# Altium schematic sheet [2] MicroController.SchDoc("[2] MicroController")
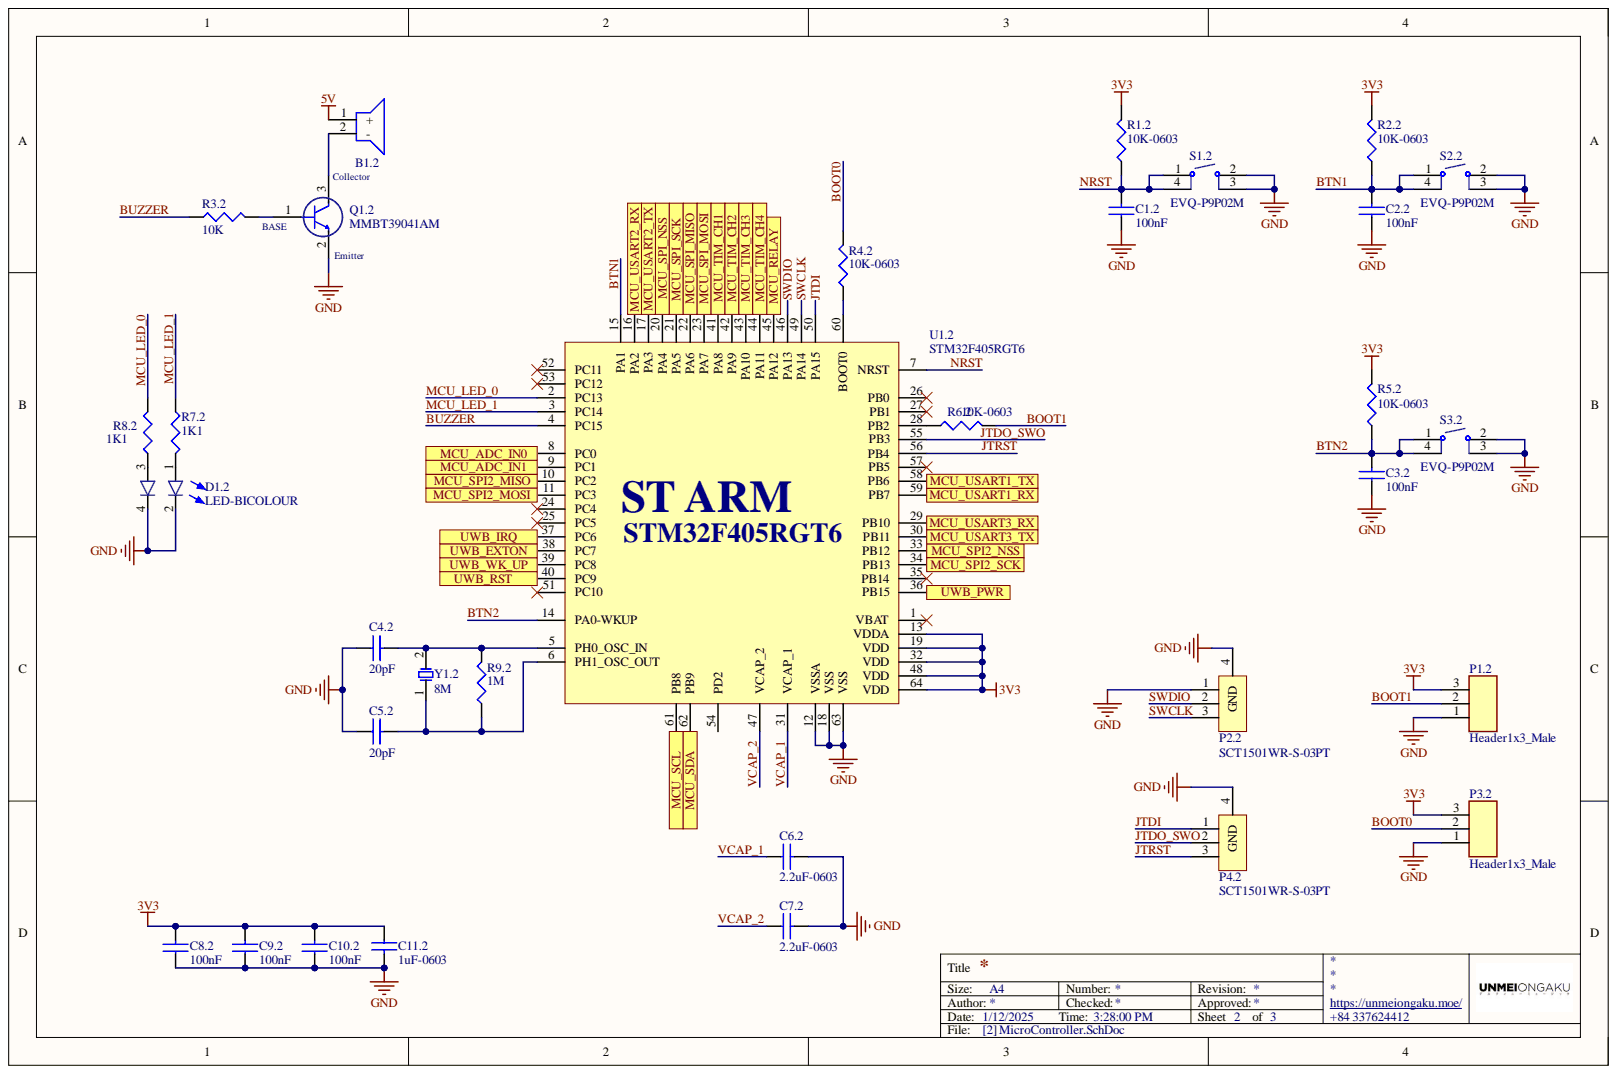
[diagram p0: [2] MicroController.SchDoc]

## Parts
B1.2  Buzzer  [Buzzer 9x5mm 60dB]  (value not drawn)
C1.2  100nF  [CAP0603]
C2.2  100nF  [CAP0603]
C3.2  100nF  [CAP0603]
C4.2  20pF  [CAP0603]
C5.2  20pF  [CAP0603]
C6.2  2.2uF-0603  [CAP0603]
C7.2  2.2uF-0603  [CAP0603]
C8.2  100nF  [CAP0603]
C9.2  100nF  [CAP0603]
C10.2  100nF  [CAP0603]
C11.2  1uF-0603  [CAP0603]
D1.2  LED-BICOLOUR  [LED0805-2COLOUR]
P1.2  Header1x3_Male  [HDR1x3_2.54_MALE]
P2.2  SCT1501WR-S-03PT  [Connector ZH1.5 3P SMD]
P3.2  Header1x3_Male  [HDR1x3_2.54_MALE]
P4.2  SCT1501WR-S-03PT  [Connector ZH1.5 3P SMD]
Q1.2  MMBT39041AM  [SOT23]
R1.2  10K-0603  [RES0603 - 3D]
R2.2  10K-0603  [RES0603 - 3D]
R3.2  10K  [RES0603 - 3D]
R4.2  10K-0603  [RES0603 - 3D]
R5.2  10K-0603  [RES0603 - 3D]
R6.2  10K-0603  [RES0603 - 3D]
R7.2  1K1  [RES0603 - 3D]
R8.2  1K1  [RES0603 - 3D]
R9.2  1M  [RES0603 - 3D]
S1.2  EVQ-P9P02M  [TactileSwitch,SMT,4.2x3.2x2.5mm,4N]
S2.2  EVQ-P9P02M  [TactileSwitch,SMT,4.2x3.2x2.5mm,4N]
S3.2  EVQ-P9P02M  [TactileSwitch,SMT,4.2x3.2x2.5mm,4N]
U1.2  STM32F405RGT6  Core Architecture: ARM  [LQFP64]
Y1.2  8M  [HC49-S]

## Ports
MCU_ADC_IN0, MCU_ADC_IN1, MCU_RELAY, MCU_SCL, MCU_SDA, MCU_SPI2_MISO, MCU_SPI2_MOSI, MCU_SPI2_NSS, MCU_SPI2_SCK, MCU_SPI_MISO, MCU_SPI_MOSI, MCU_SPI_NSS, MCU_SPI_SCK, MCU_TIM_CH1, MCU_TIM_CH2, MCU_TIM_CH3, MCU_TIM_CH4, MCU_USART1_RX, MCU_USART1_TX, MCU_USART2_RX, MCU_USART2_TX, MCU_USART3_RX, MCU_USART3_TX, UWB_EXTON, UWB_IRQ, UWB_PWR, UWB_RST, UWB_WK_UP

## Nets
3V3: C8.2.2 C9.2.2 C10.2.2 C11.2.1 P1.2.3 P3.2.3 R1.2.2 R2.2.2 R5.2.2 U1.2.13(VDDA) U1.2.19(VDD) U1.2.32(VDD) U1.2.48(VDD) U1.2.64(VDD)
5V: B1.2.1
GND: C1.2.1 C2.2.1 C3.2.1 C4.2.1 C5.2.1 C6.2.2 C7.2.2 C8.2.1 C9.2.1 C10.2.1 C11.2.2 D1.2.2 D1.2.4 P1.2.1 P2.2.1 P2.2.4(GND) P3.2.1 P4.2.4(GND) Q1.2.2 S1.2.2 S1.2.3 S2.2.2 S2.2.3 S3.2.2 S3.2.3 U1.2.12(VSSA) U1.2.18(VSS) U1.2.63(VSS)
BOOT0: P3.2.2 R4.2.2
BOOT1: P1.2.2 R6.2.2
BTN1: C2.2.2 R2.2.1 S2.2.1 S2.2.4 U1.2.15(PA1)
BTN2: C3.2.2 R5.2.1 S3.2.1 S3.2.4 U1.2.14(PA0-WKUP)
BUZZER: R3.2.1 U1.2.4(PC15)
JTDI: P4.2.1 U1.2.50(PA15)
JTDO_SWO: P4.2.2 U1.2.55(PB3)
JTRST: P4.2.3 U1.2.56(PB4)
MCU_ADC_IN0: U1.2.8(PC0) ; port MCU_ADC_IN0
MCU_ADC_IN1: U1.2.9(PC1) ; port MCU_ADC_IN1
MCU_LED_0: R8.2.2 U1.2.2(PC13)
MCU_LED_1: R7.2.1 U1.2.3(PC14)
MCU_RELAY: U1.2.45(PA12) ; port MCU_RELAY
MCU_SCL: U1.2.61(PB8) ; port MCU_SCL
MCU_SDA: U1.2.62(PB9) ; port MCU_SDA
MCU_SPI2_MISO: U1.2.10(PC2) ; port MCU_SPI2_MISO
MCU_SPI2_MOSI: U1.2.11(PC3) ; port MCU_SPI2_MOSI
MCU_SPI2_NSS: U1.2.33(PB12) ; port MCU_SPI2_NSS
MCU_SPI2_SCK: U1.2.34(PB13) ; port MCU_SPI2_SCK
MCU_SPI_MISO: U1.2.22(PA6) ; port MCU_SPI_MISO
MCU_SPI_MOSI: U1.2.23(PA7) ; port MCU_SPI_MOSI
MCU_SPI_NSS: U1.2.20(PA4) ; port MCU_SPI_NSS
MCU_SPI_SCK: U1.2.21(PA5) ; port MCU_SPI_SCK
MCU_TIM_CH1: U1.2.41(PA8) ; port MCU_TIM_CH1
MCU_TIM_CH2: U1.2.42(PA9) ; port MCU_TIM_CH2
MCU_TIM_CH3: U1.2.43(PA10) ; port MCU_TIM_CH3
MCU_TIM_CH4: U1.2.44(PA11) ; port MCU_TIM_CH4
MCU_USART1_RX: U1.2.59(PB7) ; port MCU_USART1_RX
MCU_USART1_TX: U1.2.58(PB6) ; port MCU_USART1_TX
MCU_USART2_RX: U1.2.16(PA2) ; port MCU_USART2_RX
MCU_USART2_TX: U1.2.17(PA3) ; port MCU_USART2_TX
MCU_USART3_RX: U1.2.29(PB10) ; port MCU_USART3_RX
MCU_USART3_TX: U1.2.30(PB11) ; port MCU_USART3_TX
NRST: C1.2.2 R1.2.1 S1.2.1 S1.2.4 U1.2.7(NRST)
SWCLK: P2.2.3 U1.2.49(PA14)
SWDIO: P2.2.2 U1.2.46(PA13)
UWB_EXTON: U1.2.38(PC7) ; port UWB_EXTON
UWB_IRQ: U1.2.37(PC6) ; port UWB_IRQ
UWB_PWR: U1.2.36(PB15) ; port UWB_PWR
UWB_RST: U1.2.40(PC9) ; port UWB_RST
UWB_WK_UP: U1.2.39(PC8) ; port UWB_WK_UP
VCAP_1: C6.2.1 U1.2.31(VCAP_1)
VCAP_2: C7.2.1 U1.2.47(VCAP_2)
NetB1.2_2: B1.2.2 Q1.2.3
NetC4.2_2: C4.2.2 R9.2.2 U1.2.5(PH0_OSC_IN) Y1.2.2
NetC5.2_2: C5.2.2 R9.2.1 U1.2.6(PH1_OSC_OUT) Y1.2.1
NetD1.2_1: D1.2.1 R7.2.2
NetD1.2_3: D1.2.3 R8.2.1
NetQ1.2_1: Q1.2.1 R3.2.2
NetR4.2_1: R4.2.1 U1.2.60(BOOT0)
NetR6.2_1: R6.2.1 U1.2.28(PB2)

Not connected: U1.2 1(VBAT) 24(PC4) 25(PC5) 26(PB0) 27(PB1) 35(PB14) 51(PC10) 52(PC11) 53(PC12) 54(PD2) 57(PB5)

## Library data of the parts
B1.2 = Buzzer 9050 - DIP: 9050 DIP Buzzer 9x5mm 60dB; Component Kind: Standard; Local Supplier: thegioiic; Local Supplier Link: https://www.thegioiic.com/products/1209-dip-buzzer-12x9mm; Local Supplier Part Number: 1209 DIP Buzzer 12x9mm; Library Name: Minh_Lib.SchLib
C1.2 C2.2 C3.2 C8.2 C9.2 C10.2 = CC0603KRX7R0BB104: CAP CER 0.1UF 100V X7R 0603; Manufacturer: Yageo; Tolerance: ±10%; Applications: General Purpose; Category: Capacitors; Component Kind: Standard; ComponentLink1Description: http://media.digikey.com/pdf/Data%20Sheets/Yageo%20PDFs/NPO,%20X7R%20Mid-voltage.pdf; ComponentLink1URL: http://media.digikey.com/pdf/Data%20Sheets/Yageo%20PDFs/NPO,%20X7R%20Mid-voltage.pdf; Failure Rate: -; Features: -; Height - Seated (Max): -; Lead Spacing: -; Lead Style: -; Manufacturer Part Number: CC0603KRX7R0BB104; Mounting Type: Surface Mount, MLCC; Operating Temperature: -55°C ~ 125°C; Package / Case: 0603 (1608 Metric); Packaging: Cut Tape (CT); Part Status: Active; Pricing 1: 1=0.23, 10=0.156, 100=0.0791, 500=0.05414, 1000=0.0479 (USD); Ratings: -; RoHS: RoHS Compliant; Size / Dimension: 0.063" L x 0.032" W (1.60mm x 0.80mm); Stock 1: 784508; Supplier 1: Digi-Key; Supplier Part Number 1: 311-1523-1-ND; Temperature Coefficient: X7R; Thickness (Max): 0.035" (0.90mm); Value: 100nF; Voltage - Rated: 100V; Library Name: Minh_Lib.SchLib
C4.2 C5.2 = CC0603JRNPO9BN200: CAP CER 20PF 50V C0G/NPO 0603; Manufacturer 1: Yageo; Category 1: Capacitors; Temperature Coefficient: C0G, NP0; Tolerance: ±5%; Applications: General Purpose; Capacitance: 20pF; Component Kind: Standard; ComponentLink1Description: http://www.yageo.com/documents/recent/UPY-GP_NP0_16V-to-50V_16.pdf; ComponentLink1URL: http://www.yageo.com/documents/recent/UPY-GP_NP0_16V-to-50V_16.pdf; Description 1: CAP CER 20PF 50V C0G/NPO 0603; Failure Rate: -; Features: -; Height - Seated (Max): -; Lead Spacing: -; Lead Style: -; Manufacturer Part Number 1: CC0603JRNPO9BN200; Mounting Type: Surface Mount, MLCC; Operating Temperature: -55°C ~ 125°C; Package / Case: 0603 (1608 Metric); Packaging: Cut Tape (CT); Part Status: Active; Pricing 1: 1=0.1, 10=0.037, 100=0.0164, 500=0.01172, 1000=0.0092 (USD); Ratings: -; RoHS 1: RoHS Compliant; Size / Dimension: 0.063" L x 0.031" W (1.60mm x 0.80mm); Stock 1: 67895; Supplier 1: Digi-Key; Supplier Part Number 1: 311-1424-1-ND; Thickness (Max): 0.035" (0.90mm); Value: 20pF; Voltage - Rated: 50V; Library Name: Minh_Lib.SchLib
C6.2 C7.2 = CC0603KRX7R6BB225: CAP CER 2.2UF 10V X7R 0603; Manufacturer 1: Yageo; Capacitance: 2.2µF; Category 1: Capacitors; Tolerance: ±10%; Applications: General Purpose; Component Kind: Standard; ComponentLink1Description: http://www.yageo.com/documents/recent/UPY-GPHC_X7R_6.3V-to-50V_18.pdf; ComponentLink1URL: http://www.yageo.com/documents/recent/UPY-GPHC_X7R_6.3V-to-50V_18.pdf; Description 1: CAP CER 2.2UF 10V X7R 0603; Failure Rate: -; Features: -; Height - Seated (Max): -; Lead Spacing: -; Lead Style: -; Manufacturer Part Number 1: CC0603KRX7R6BB225; Mounting Type: Surface Mount, MLCC; Operating Temperature: -55°C ~ 125°C; Package / Case: 0603 (1608 Metric); Packaging: Cut Tape (CT); Part Status: Active; Pricing 1: 1=0.32, 10=0.219, 100=0.1238, 500=0.0874, 1000=0.07648 (USD); Ratings: -; RoHS 1: RoHS Compliant; Size / Dimension: 0.063" L x 0.031" W (1.60mm x 0.80mm); Stock 1: 14291; Supplier 1: Digi-Key; Supplier Part Number 1: 311-1797-1-ND; Temperature Coefficient: X7R; Thickness (Max): 0.035" (0.90mm); Value: 2.2uF; Voltage - Rated: 10V; Library Name: Minh_Lib.SchLib
C11.2 = CC0603KRX7R7BB105: CAP CER 1UF 16V X7R 0603; Manufacturer 1: Yageo; Capacitance: 1µF; Category 1: Capacitors; Tolerance: ±10%; Applications: General Purpose; Component Kind: Standard; ComponentLink1Description: http://www.yageo.com/documents/recent/UPY-GPHC_X7R_6.3V-to-50V_18.pdf; ComponentLink1URL: http://www.yageo.com/documents/recent/UPY-GPHC_X7R_6.3V-to-50V_18.pdf; Description 1: CAP CER 1UF 16V X7R 0603; Failure Rate: -; Features: -; Height - Seated (Max): -; Lead Spacing: -; Lead Style: -; Manufacturer Part Number 1: CC0603KRX7R7BB105; Mounting Type: Surface Mount, MLCC; Operating Temperature: -55°C ~ 125°C; Package / Case: 0603 (1608 Metric); Packaging: Cut Tape (CT); Part Status: Active; Pricing 1: 1=0.1, 10=0.051, 100=0.0227, 500=0.0162, 1000=0.01274 (USD); Ratings: -; RoHS 1: RoHS Compliant; Size / Dimension: 0.063" L x 0.031" W (1.60mm x 0.80mm); Stock 1: 274580; Supplier 1: Digi-Key; Supplier Part Number 1: 311-1446-1-ND; Temperature Coefficient: X7R; Thickness (Max): 0.035" (0.90mm); Value: 1uF; Voltage - Rated: 16V; Library Name: Minh_Lib.SchLib
P1.2 P3.2 = HDR1x3_2.54_MALE: Header1x3_2.54_MALE; Component Kind: Standard; Library Name: Minh_Lib.SchLib
Q1.2 = MMBT3904-7-F / 1AM: Transistor General Purpose BJT NPN 40 Volt 0.2A Automotive 3-Pin SOT-23; Manufacturer: Diodes Zetex; Collector Base Voltage (VCBO): 60V; Collector Emitter Breakdown Voltage: 40V; Collector Emitter Saturation Voltage: 300mV; Continuous Collector Current: 200mA; Element Configuration: Single; Emitter Base Voltage (VEBO): 6V; Frequency: 300MHz; hFE Min: 100; Max Power Dissipation: 300mW; Number of Elements: 1; Power Dissipation: 350mW; Case/Package: SOT-23; Category: BJTs; Collector Emitter Voltage (VCEO): 40V; Collector-emitter Voltage-Max: 300mV; Component Kind: Standard; ComponentLink1Description: https://datasheet.ciiva.com/11201/ds30036-11201778.pdf?src-supplier=Avnet; ComponentLink1URL: https://datasheet.ciiva.com/11201/ds30036-11201778.pdf?src-supplier=Avnet; ComponentLink2Description: https://datasheet.ciiva.com/15138/getdatasheetpartid-53265-15138780.pdf?src-supplier=Verical; ComponentLink2URL: https://datasheet.ciiva.com/15138/getdatasheetpartid-53265-15138780.pdf?src-supplier=Verical; ComponentLink3Description: https://datasheet.ciiva.com/22711/1915516-22711750.pdf?src-supplier=Element14; ComponentLink3URL: https://datasheet.ciiva.com/22711/1915516-22711750.pdf?src-supplier=Element14; ComponentLink4Description: https://datasheet.ciiva.com/11198/ds30036-11198999.pdf?src-supplier=Avnet; ComponentLink4URL: https://datasheet.ciiva.com/11198/ds30036-11198999.pdf?src-supplier=Avnet; ComponentLink5Description: https://datasheet.ciiva.com/6361/ds30036-6361256.pdf?src-supplier=Digi-Key; ComponentLink5URL: https://datasheet.ciiva.com/6361/ds30036-6361256.pdf?src-supplier=Digi-Key; ComponentLink6Description: https://datasheet.ciiva.com/6361/ds30036-6361254.pdf?src-supplier=Digi-Key; ComponentLink6URL: https://datasheet.ciiva.com/6361/ds30036-6361254.pdf?src-supplier=Digi-Key; Contact Plating: Tin,Matte; Gain Bandwidth Product: 300MHz; Height: 1mm; Lead Free: Lead Free; Length: 3.05mm; Manufacturer Part Number: MMBT3904-7-F; Max Breakdown Voltage: 40V; Max Collector Current: 200mA; Max Frequency: 300MHz; Max Operating Temperature: 150°C; Min Operating Temperature: -55°C; Mount: Surface Mount; Number of Pins: 3; Package Quantity: 3000; Packaging: Tape and Reel; Polarity: NPN; Pricing 1: 3000=0.02271, 6000=0.02048, 15000=0.01781, 30000=0.01603, 75000=0.01425 (USD); Radiation Hardening: No; REACH SVHC: No SVHC; RoHS: Yes; RoHS Compliant: Yes; Series: -; Stock 1: 468030; Supplier 1: Digi-Key; Supplier Part Number 1: MMBT3904-FDITR-ND; Transition Frequency: 300MHz; Voltage Rating (DC): 40V; Weight: 0.000282oz; Width: 1.4mm; Library Name: Minh_Lib.SchLib
R1.2 R2.2 R4.2 R5.2 R6.2 = RC0603JR-0710KL: RES SMD 10K OHM 5% 1/10W 0603; Manufacturer 1: Yageo; Category 1: Resistors; Power (Watts): 0.1W, 1/10W; Temperature Coefficient: ±100ppm/°C; Component Kind: Standard; ComponentLink1Description: http://www.yageo.com/documents/recent/PYu-RC_Group_51_RoHS_L_7.pdf; ComponentLink1URL: http://www.yageo.com/documents/recent/PYu-RC_Group_51_RoHS_L_7.pdf; Composition: Thick Film; Description 1: RES SMD 10K OHM 5% 1/10W 0603; Failure Rate: -; Features: Moisture Resistant; Height - Seated (Max): 0.022" (0.55mm); Manufacturer Part Number 1: RC0603JR-0710KL; Operating Temperature: -55°C ~ 155°C; Package / Case: 0603 (1608 Metric); Packaging: Cut Tape (CT); Part Status: Active; Pricing 1: 1=0.1, 10=0.011, 100=0.0044, 1000=0.00198, 2500=0.00172 (USD); Resistance (Ohms): 10k; RoHS 1: RoHS Compliant; Size / Dimension: 0.063" L x 0.031" W (1.60mm x 0.80mm); Stock 1: 3056463; Supplier 1: Digi-Key; Supplier Device Package: 0603; Supplier Part Number 1: 311-10KGRCT-ND; Tolerance: ±5%; Value: 10K; Library Name: Minh_Lib.SchLib
R3.2 = RC0603FR-0710KL: RES SMD 10K OHM 1% 1/10W 0603; Manufacturer: Yageo; Power (Watts): 0.1W, 1/10W; Temperature Coefficient: ±100ppm/°C; Category: Resistors; Component Kind: Standard; ComponentLink1Description: http://www.yageo.com/documents/recent/PYu-RC_Group_51_RoHS_L_7.pdf; ComponentLink1URL: http://www.yageo.com/documents/recent/PYu-RC_Group_51_RoHS_L_7.pdf; Composition: Thick Film; Failure Rate: -; Features: Moisture Resistant; Height - Seated (Max): 0.022" (0.55mm); Manufacturer Part Number: RC0603FR-0710KL; Operating Temperature: -55°C ~ 155°C; Package / Case: 0603 (1608 Metric); Packaging: Cut Tape (CT); Part Status: Active; Pricing 1: 1=0.1, 10=0.015, 100=0.006, 1000=0.00268, 2500=0.00233 (USD); RoHS: RoHS Compliant; Size / Dimension: 0.063" L x 0.032" W (1.60mm x 0.80mm); Stock 1: 16545228; Supplier 1: Digi-Key; Supplier Device Package: 0603; Supplier Part Number 1: 311-10.0KHRCT-ND; Tolerance: ±1%; Value: 10K; Library Name: Minh_Lib.SchLib
R7.2 R8.2 = RC0603FR-071K1L: RES SMD 1.1K OHM 1% 1/10W 0603; Manufacturer: Yageo; Power (Watts): 0.1W, 1/10W; Temperature Coefficient: ±100ppm/°C; Category: Resistors; Component Kind: Standard; ComponentLink1Description: http://www.yageo.com/documents/recent/PYu-RC_Group_51_RoHS_L_7.pdf; ComponentLink1URL: http://www.yageo.com/documents/recent/PYu-RC_Group_51_RoHS_L_7.pdf; Composition: Thick Film; Failure Rate: -; Features: Moisture Resistant; Height - Seated (Max): 0.022" (0.55mm); Manufacturer Part Number: RC0603FR-071K1L; Operating Temperature: -55°C ~ 155°C; Package / Case: 0603 (1608 Metric); Packaging: Cut Tape (CT); Part Status: Active; Pricing 1: 1=0.1, 10=0.015, 100=0.006, 1000=0.00268, 2500=0.00233 (USD); RoHS: RoHS Compliant; Size / Dimension: 0.063" L x 0.032" W (1.60mm x 0.80mm); Stock 1: 427612; Supplier 1: Digi-Key; Supplier Device Package: 0603; Supplier Part Number 1: 311-1.10KHRCT-ND; Tolerance: ±1%; Value: 1K1; Library Name: Minh_Lib.SchLib
R9.2 = RC0603JR-071ML: RES SMD 1M OHM 5% 1/10W 0603; Manufacturer 1: Yageo; Category 1: Resistors; Power (Watts): 0.1W, 1/10W; Temperature Coefficient: ±100ppm/°C; Component Kind: Standard; ComponentLink1Description: http://www.yageo.com/documents/recent/PYu-RC_Group_51_RoHS_L_7.pdf; ComponentLink1URL: http://www.yageo.com/documents/recent/PYu-RC_Group_51_RoHS_L_7.pdf; Composition: Thick Film; Description 1: RES SMD 1M OHM 5% 1/10W 0603; Failure Rate: -; Features: Moisture Resistant; Height - Seated (Max): 0.022" (0.55mm); Manufacturer Part Number 1: RC0603JR-071ML; Operating Temperature: -55°C ~ 155°C; Package / Case: 0603 (1608 Metric); Packaging: Cut Tape (CT); Part Status: Active; Pricing 1: 1=0.1, 10=0.011, 100=0.0044, 1000=0.00198, 2500=0.00172 (USD); Resistance (Ohms): 1M; RoHS 1: RoHS Compliant; Size / Dimension: 0.063" L x 0.031" W (1.60mm x 0.80mm); Stock 1: 1727458; Supplier 1: Digi-Key; Supplier Device Package: 0603; Supplier Part Number 1: 311-1.0MGRCT-ND; Tolerance: ±5%; Value: 1M; Library Name: Minh_Lib.SchLib
S1.2 S2.2 S3.2 = EVQ-P9P02M: Switch Tactile N.O. SPST Rectangular Button J-Bend 0.02A 15VDC 5N SMD Embossed Tape and Reel; Manufacturer: Panasonic; Contact Current Rating: 20mA; Illumination Type: Non-Illuminated; Max Voltage Rating (DC): 15V; Mechanical Life: 200000Cycles; Number of Positions: 2; Operating Force: 500gf; Switch Function: Off-Mom; Switch Travel: 0.25mm; Case/Package: SMD/SMT; Category: Tactile Switches; Component Kind: Standard; ComponentLink1Description: https://datasheet.ciiva.com/29974/atv0000ce7-29974075.pdf?src-supplier=Avnet; ComponentLink1URL: https://datasheet.ciiva.com/29974/atv0000ce7-29974075.pdf?src-supplier=Avnet; ComponentLink2Description: https://datasheet.ciiva.com/14920/atv0000ce7-14920757.pdf?src-supplier=Digikey; ComponentLink2URL: https://datasheet.ciiva.com/14920/atv0000ce7-14920757.pdf?src-supplier=Digikey; ComponentLink3Description: https://datasheet.ciiva.com/14920/atv0000ce7-14920758.pdf?src-supplier=Digikey; ComponentLink3URL: https://datasheet.ciiva.com/14920/atv0000ce7-14920758.pdf?src-supplier=Digikey; Lead Free: Lead Free; Manufacturer Part Number: EVQ-P9P02M; Max Operating Temperature: 85°C; Min Operating Temperature: -40°C; Mount: Surface Mount; Package Quantity: 4000; Packaging: Tape and Reel; Pricing 1: 1=0.83, 10=0.734, 50=0.734, 100=0.61, 1000=0.5, 10000=0.402 (USD); Radiation Hardening: No; RoHS: No; RoHS Compliant: No; Series: EVQP9; Size: 3.5mm; Stock 1: 5205; Supplier 1: Mouser; Supplier Part Number 1: 667-EVQ-P9P02M; Termination: J-Bend; Throw Configuration: SPST; Library Name: Minh_Lib.SchLib
U1.2 = STM32F405RGT6: IC MCU 32BIT 1MB FLASH 64LQFP; Manufacturer: STMicroelectronics; Data Bus Width: 32b; Frequency: 168MHz; Interface: I2C,SPI,USB,CAN,IrDA,UART,USART,LIN,I2S; Max Supply Voltage: 3.6V; Memory Size: 1024KB; Min Supply Voltage: 1.8V; Number of ADC Channels: 16; Number of I2C Channels: 3; Number of I/Os: 51; Number of PWM Channels: 2; Number of Timers/Counters: 14; Oscillator Type: Internal; Peripherals: Brown-out Detect/Reset,POR,PWM,WDT,DMA,LCD,I2C; RAM Size: 196KB; Watchdog Timer: Yes; Case/Package: LQFP; Category: Microcontrollers; Component Kind: Standard; ComponentLink1Description: https://datasheet.ciiva.com/8055/1717982-8055024.pdf?src-supplier=Element14; ComponentLink1URL: https://datasheet.ciiva.com/8055/1717982-8055024.pdf?src-supplier=Element14; ComponentLink2Description: https://datasheet.ciiva.com/4837/dm00037051-492832-4837997.pdf?src-supplier=Mouser; ComponentLink2URL: https://datasheet.ciiva.com/4837/dm00037051-492832-4837997.pdf?src-supplier=Mouser; Height: 1.45mm; Lead Free: Lead Free; Length: 10mm; Manufacturer Part Number: STM32F405RGT6; Max Frequency: 168MHz; Max Operating Temperature: 85°C; Memory Type: FLASH; Min Operating Temperature: -40°C; Number of Pins: 64; Number of Programmable I/O: 51; Number of SPI Channels: 3; Number of UART Channels: 2; Package Quantity: 960; Packaging: Tray; Pricing 1: 1=16.96000, 10=15.60000, 25=14.94000, 50=14.35000, 100=13.76000, 250=13.17000, 500=11.78000 (USD); Radiation Hardening: No; Reach SVHC Compliant: Yes; RoHS: Yes; RoHS Compliant: Yes; Series: STM32 F4; Supplier 1: Newark; Supplier Part Number 1: 71T9854; Width: 10mm; Library Name: Minh_Lib.SchLib
Y1.2 = Crystals - HC49SSDLF-8.00MHz: Crystals LO-PRO 8MHz; Manufacturer: Fox Electronics; Category: Uncategorized; Component Kind: Standard; ComponentLink1Description: https://datasheet.ciiva.com/12388/hc49ssdlf-16337-12388064.pdf?src-supplier=Mouser; ComponentLink1URL: https://datasheet.ciiva.com/12388/hc49ssdlf-16337-12388064.pdf?src-supplier=Mouser; Frequency: 8MHz; Height: 3.2mm; Length: 13.2mm; Manufacturer Part Number: HC49SSDLF-8.00MHz; Package Quantity: 1000; Packaging: Tape and Reel; RoHS: Yes; Supplier 1: Mouser; Supplier Part Number 1: 559-280LF-080; Termination: SMD/SMT; Width: 5mm; Library Name: Minh_Lib.SchLib
D1.2 = LED-BICOLOUR: Component Kind: Standard; Library Name: Minh_Lib.SchLib
P2.2 P4.2 = SCT1501WR-S-03PT: Component Kind: Standard; Library Name: Minh_Lib.SchLib
